# Altium schematic sheet TSAL.SchDoc
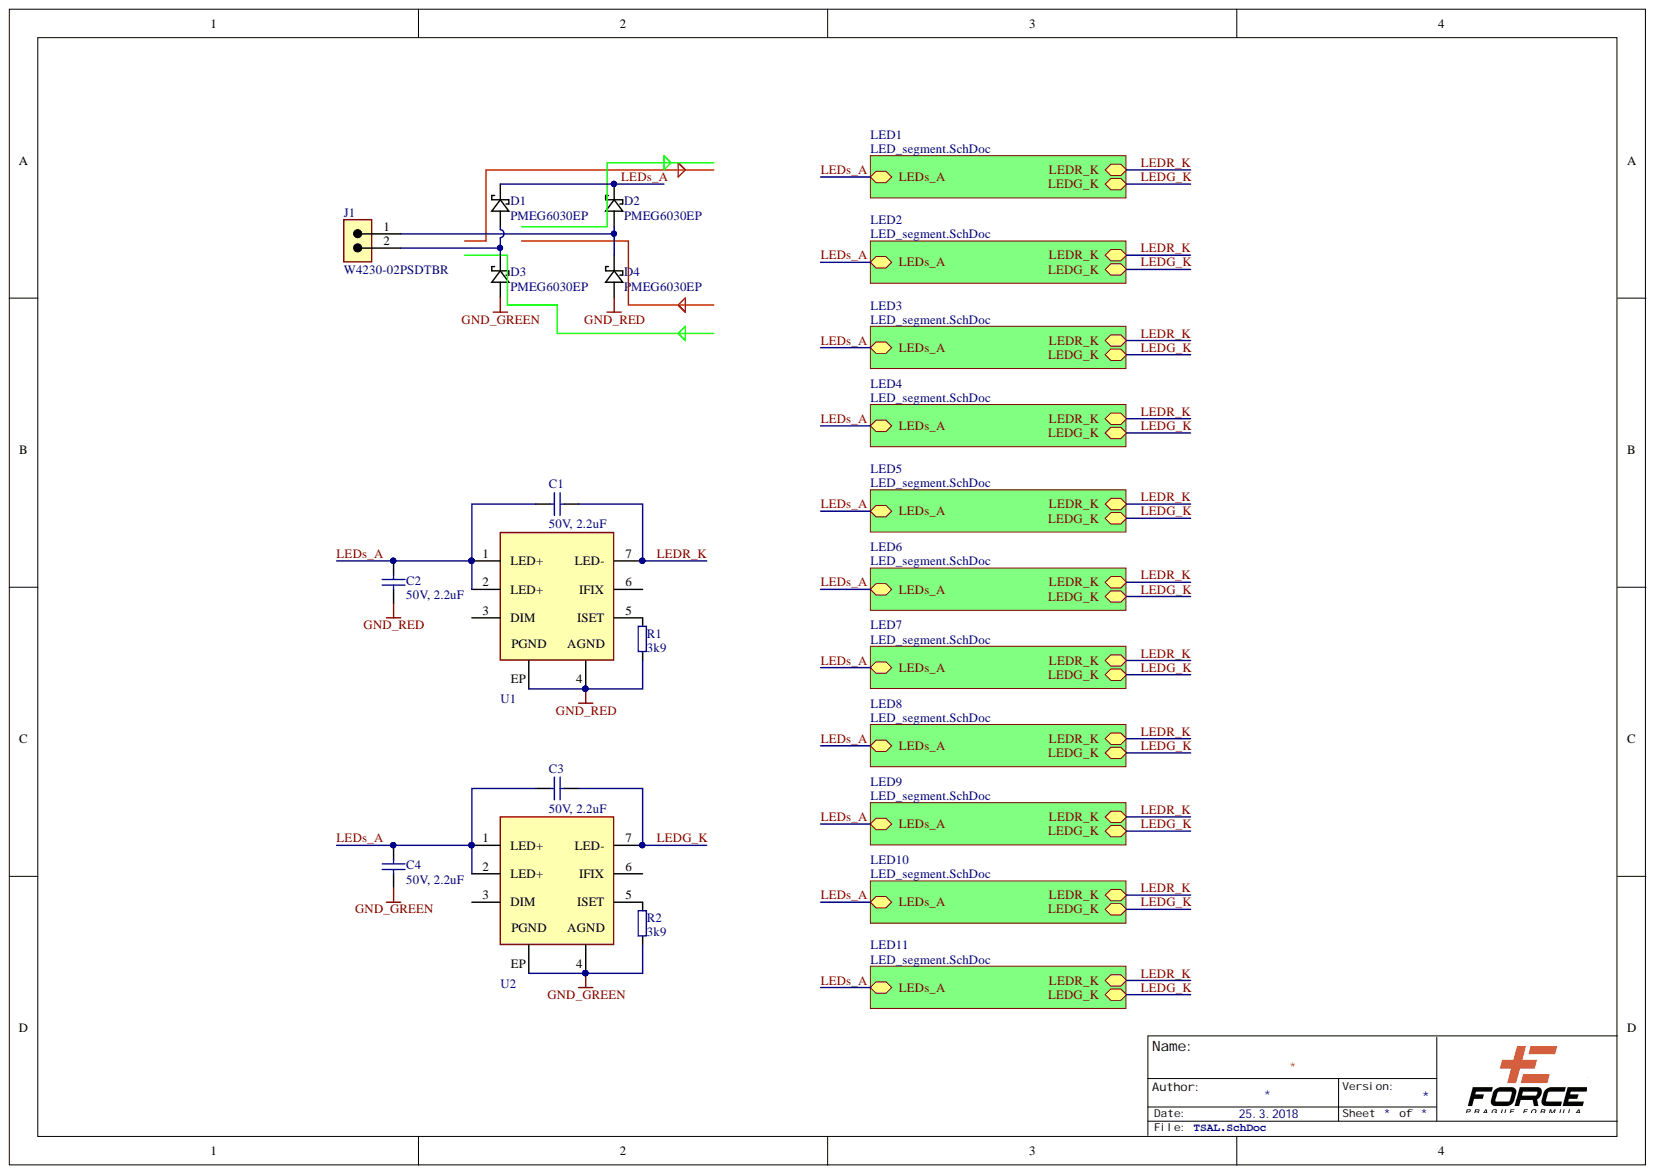
[diagram p0: TSAL.SchDoc]

## Parts
C1  50V, 2.2uF  value 2.2uF  [1812_4.5x3.2x2.5mm]
C2  50V, 2.2uF  value 2.2uF  [1812_4.5x3.2x2.5mm]
C3  50V, 2.2uF  value 2.2uF  [1812_4.5x3.2x2.5mm]
C4  50V, 2.2uF  value 2.2uF  [1812_4.5x3.2x2.5mm]
D1  PMEG6030EP  [SOD128]
D2  PMEG6030EP  [SOD128]
D3  PMEG6030EP  [SOD128]
D4  PMEG6030EP  [SOD128]
J1  W4230-02PSDTBR  [W4230-02PSDTBR]
R1  value 3k9  [RES_0603]  (hidden comment: Thick film)
R2  value 3k9  [RES_0603]  (hidden comment: Thick film)
U1  172946001  [WE-LDHM_TOP263-7EP]  (value not drawn)
U2  172946001  [WE-LDHM_TOP263-7EP]  (value not drawn)

## Nets
GND_GREEN: C4.1 D3.A R2.1 U2.4 U2.EP
GND_RED: C2.1 D4.A R1.1 U1.4 U1.EP
LEDG_K: C3.1 U2.7(LED-)
LEDR_K: C1.1 U1.7(LED-)
LEDs_A: C1.2 C2.2 C3.2 C4.2 D1.C D2.C U1.1(LED+) U1.2(LED+) U2.1(LED+) U2.2(LED+)
NetD1_A: D1.A D3.C J1.2
NetD2_A: D2.A D4.C J1.1
NetR1_2: R1.2 U1.5(ISET)
NetR2_2: R2.2 U2.5(ISET)
NetU1_3: U1.3(DIM)
NetU1_6: U1.6(IFIX)
NetU2_3: U2.3(DIM)
NetU2_6: U2.6(IFIX)

## Library data of the parts
C1-C4 = 885012210032: WCAP-CSGP Ceramic Capacitors, 1812, X7R, 50V, 2.2uF; Rated Voltage: 50V DC; Ceramic Type: X7R; Component Kind: Standard; ComponentLink1Description: Manufacturer; ComponentLink1URL: http://www.we-online.de; ComponentLink2Description: Datasheet; ComponentLink2URL: http://katalog.we-online.de/pbs/datasheet/885012210032.pdf; Library Name: ALTIUM-WCAP-CSGP-1812-rev17b (1).IntLib; Operating Temperature: -55 °C to +125 °C; Part Number: 885012210032; Published Date: Oct. 2017; Size: 1812; Publisher: Wurth Elektronik
  C2 C4: Value: 2.2uF
D1-D4 = SCHOTTKY_3A_60V_PMEG6030EP: Schottky dioda vseobecne; Component Kind: Standard; Supplier 1: Farnell; Supplier Part Number 1: 1829207; Library Name: ECUP.IntLib
J1 = EJ+W4230-02PSDTBRXX0: Micro-Fit 3.0 Header, SMT, Single Row, Horizontal, with Solder  Tab, 2 Circuits, Tin Plating; consumption: 3100; Migrated & Cleaned up: Yes; on_stock: 4798; RPP: 5,88; Tolerance & Rating: 5A AC, DC/ 250V AC, DC/ 10mohm; Component Kind: Standard; ComponentLink1Description: EJ+W4230-02PSDTBRXX0-a.jpg; ComponentLink2Description: EJ+W4230-02PSDTBRXX0-b.jpg; ComponentLink3Description: konektor SMD; ComponentLink3URL: heliosgreen://v1/hegMT/5-MT/Classes(343)/Folders/OpenForm?RecordNumbers=[2283292]; KK: EJ+W4230-02PSDTBRXX0; Library Name: Production_Library.IntLib; SMD: 1; Value: W4230-02PSDTBR; Author: JP/31.3.2014; ComponentLink1URL: i:\DataSheets\EJ+W4230-02PSDTBRXX0_HSUAN MAO.pdf; ComponentLink2URL: i:\DataSheets\SMD_parts_pictures\EJ+W4230-02PSDTBRXX0-a.jpg
R1 R2 = RES_3k9_5%_0603: 3900.00; Case [EIA]: 0603; Case [Metric]: 1608; Power Rating [W]: 0.1; Tolerance [%]: ±5; Component Kind: Standard; Library Name: all_in_one_library.IntLib; Value [Ω]: 3900.00
U1 U2 = 172946001: MagI³C-LDHM LED Step Down High Current Module, TOP263-7EP; InputVoltage: 4.5-60V; OutputCurrent: 0.45A; Component Kind: Standard; ComponentLink1Description: Manufacturer; ComponentLink1URL: http://www.we-online.de; ComponentLink2Description: Datasheet; ComponentLink2URL: http://katalog.we-online.de/pm/datasheet/172946001.pdf; PartNumber: 172946001; PublishedDate: Aug 2016; Size: TOP263-7EP; Library Name: ALTIUM-MAGIC-LDHM-rev16a.IntLib; Publisher: Wurth Elektronik
